# Altium schematic sheet TFT.SchDoc
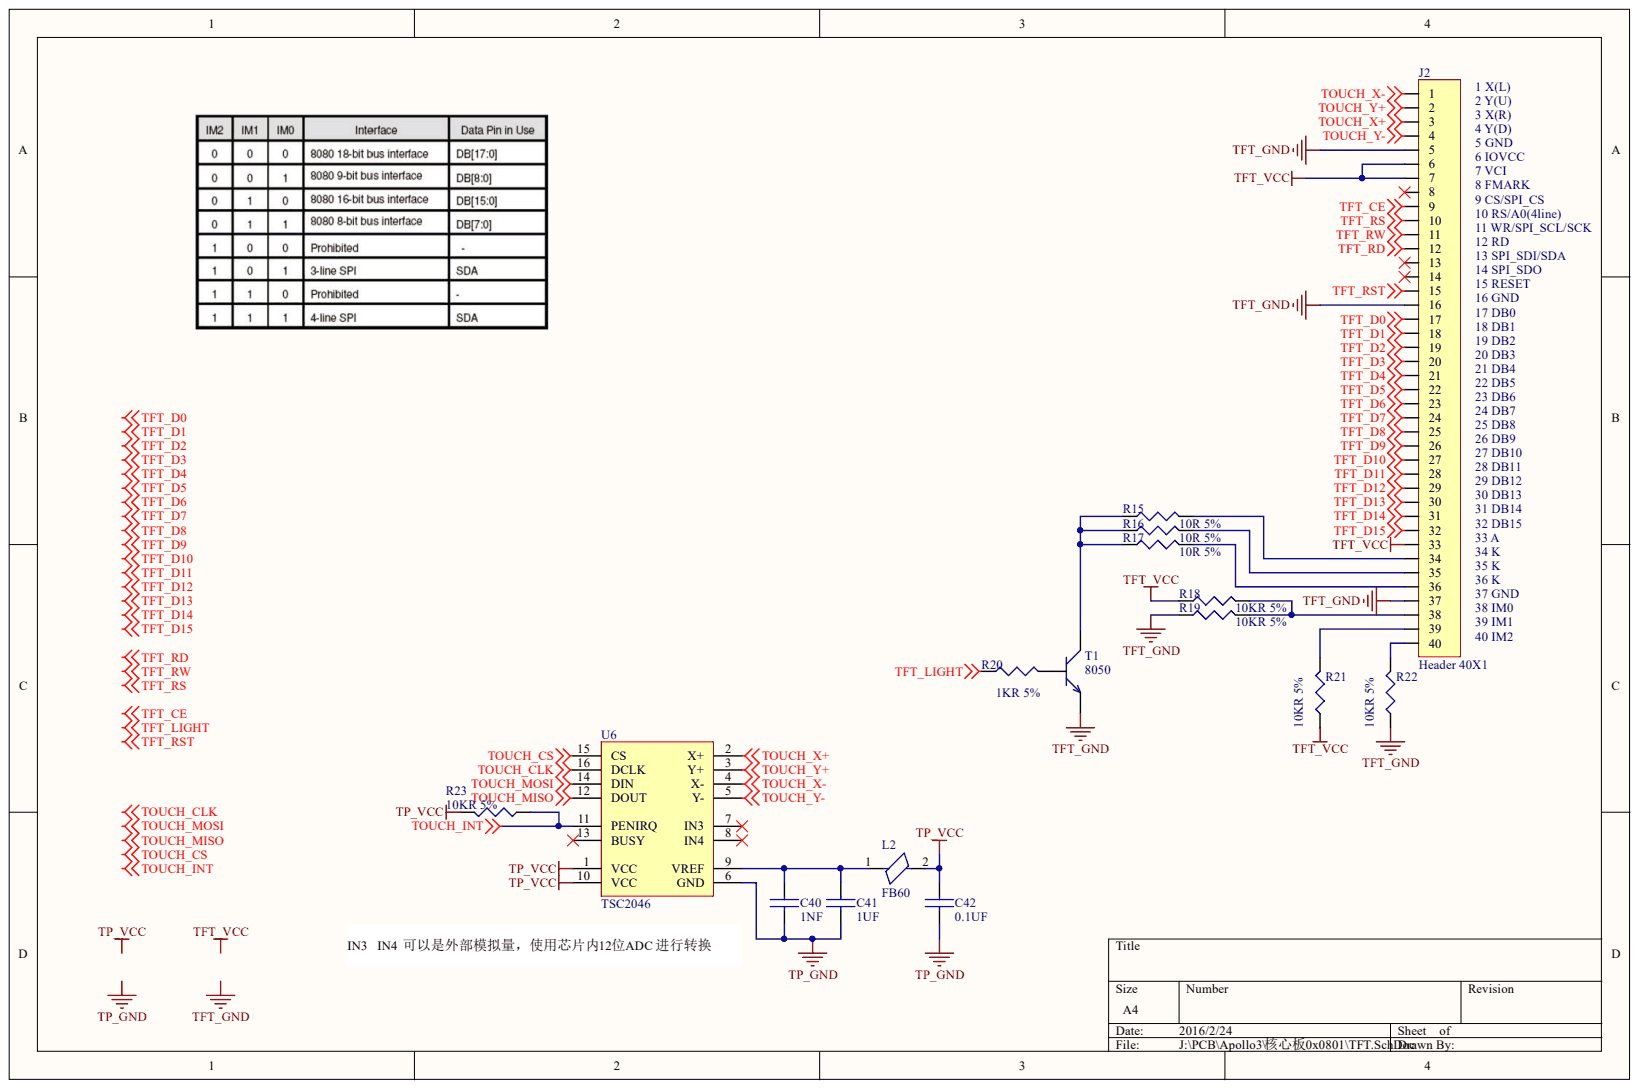
[diagram p0: TFT.SchDoc]

## Parts
C40  1NF  [CAPC1005X05N]
C41  1UF  [CAPC1005X05N]
C42  0.1UF  [CAPC1005X05N]
J2  Header 40X1  [FPC 0.5X40]
L2  FB60  [R0603]
R15  10R 5%  [RESC1005X04N]
R16  10R 5%  [RESC1005X04N]
R17  10R 5%  [RESC1005X04N]
R18  10KR 5%  [RESC1005X04N]
R19  10KR 5%  [RESC1005X04N]
R20  1KR 5%  [RESC1005X04N]
R21  10KR 5%  [RESC1005X04N]
R22  10KR 5%  [RESC1005X04N]
R23  10KR 5%  [RESC1005X04N]
T1  8050  [SOT23-3_95P240X100-3N]
U6  TSC2046  [TSOP65P640X120-16N2]

## Nets
TFT_CE: J2.9
TFT_D0: J2.17
TFT_D1: J2.18
TFT_D2: J2.19
TFT_D3: J2.20
TFT_D4: J2.21
TFT_D5: J2.22
TFT_D6: J2.23
TFT_D7: J2.24
TFT_D8: J2.25
TFT_D9: J2.26
TFT_D10: J2.27
TFT_D11: J2.28
TFT_D12: J2.29
TFT_D13: J2.30
TFT_D14: J2.31
TFT_D15: J2.32
TFT_GND: J2.5 J2.16 J2.37 R19.1 R22.1 T1.2
TFT_LIGHT: R20.1
TFT_RD: J2.12
TFT_RS: J2.10
TFT_RST: J2.15
TFT_RW: J2.11
TFT_VCC: J2.6 J2.7 J2.33 R18.1 R21.1
TOUCH_CLK: U6.16(DCLK)
TOUCH_CS: U6.15(CS)
TOUCH_INT: R23.1 U6.11(PENIRQ)
TOUCH_MISO: U6.12(DOUT)
TOUCH_MOSI: U6.14(DIN)
TOUCH_X+: J2.3 U6.2(X+)
TOUCH_X-: J2.1 U6.4(X-)
TOUCH_Y+: J2.2 U6.3(Y+)
TOUCH_Y-: J2.4 U6.5(Y-)
TP_GND: C40.2 C41.2 C42.2 U6.6(GND)
TP_VCC: C42.1 L2.2 R23.2 U6.1(VCC) U6.10(VCC)
NetC40_1: C40.1 C41.1 L2.1 U6.9(VREF)
NetJ2_34: J2.34 R15.2
NetJ2_35: J2.35 R16.2
NetJ2_36: J2.36 R17.2
NetJ2_38: J2.38 R18.2 R19.2
NetJ2_39: J2.39 R21.2
NetJ2_40: J2.40 R22.2
NetR15_1: R15.1 R16.1 R17.1 T1.3
NetR20_2: R20.2 T1.1

Not connected: J2 8 13 14; U6 7(IN3) 8(IN4) 13(BUSY)

## Library data of the parts
C40-C42 = CAP: Capacitor nonpolarity; Component Kind: Standard; Library Name: RCL_Standard.SchLib
J2 = Header 40X1: Header 40X1,FPC; Component Kind: Standard; Library Name: Connector.SchLib
L2 = BEAD_ FERRITE: Ferrite bead; Component Kind: Standard; Library Name: RCL_Standard.SchLib
R15-R23 = R: General Resistors; Component Kind: Standard; Library Name: RCL_Standard.SchLib
T1 = NPN: Triode,9013,9014,8050; Component Kind: Standard; Library Name: Transistor.SchLib
U6 = TSC2046: 电阻触摸屏控制 IC, 与ADS7843引脚一致; Component Kind: Standard; Library Name: IC.SchLib
